# Altium schematic sheet Arduino_DIY.SchDoc(Arduino_DIY)
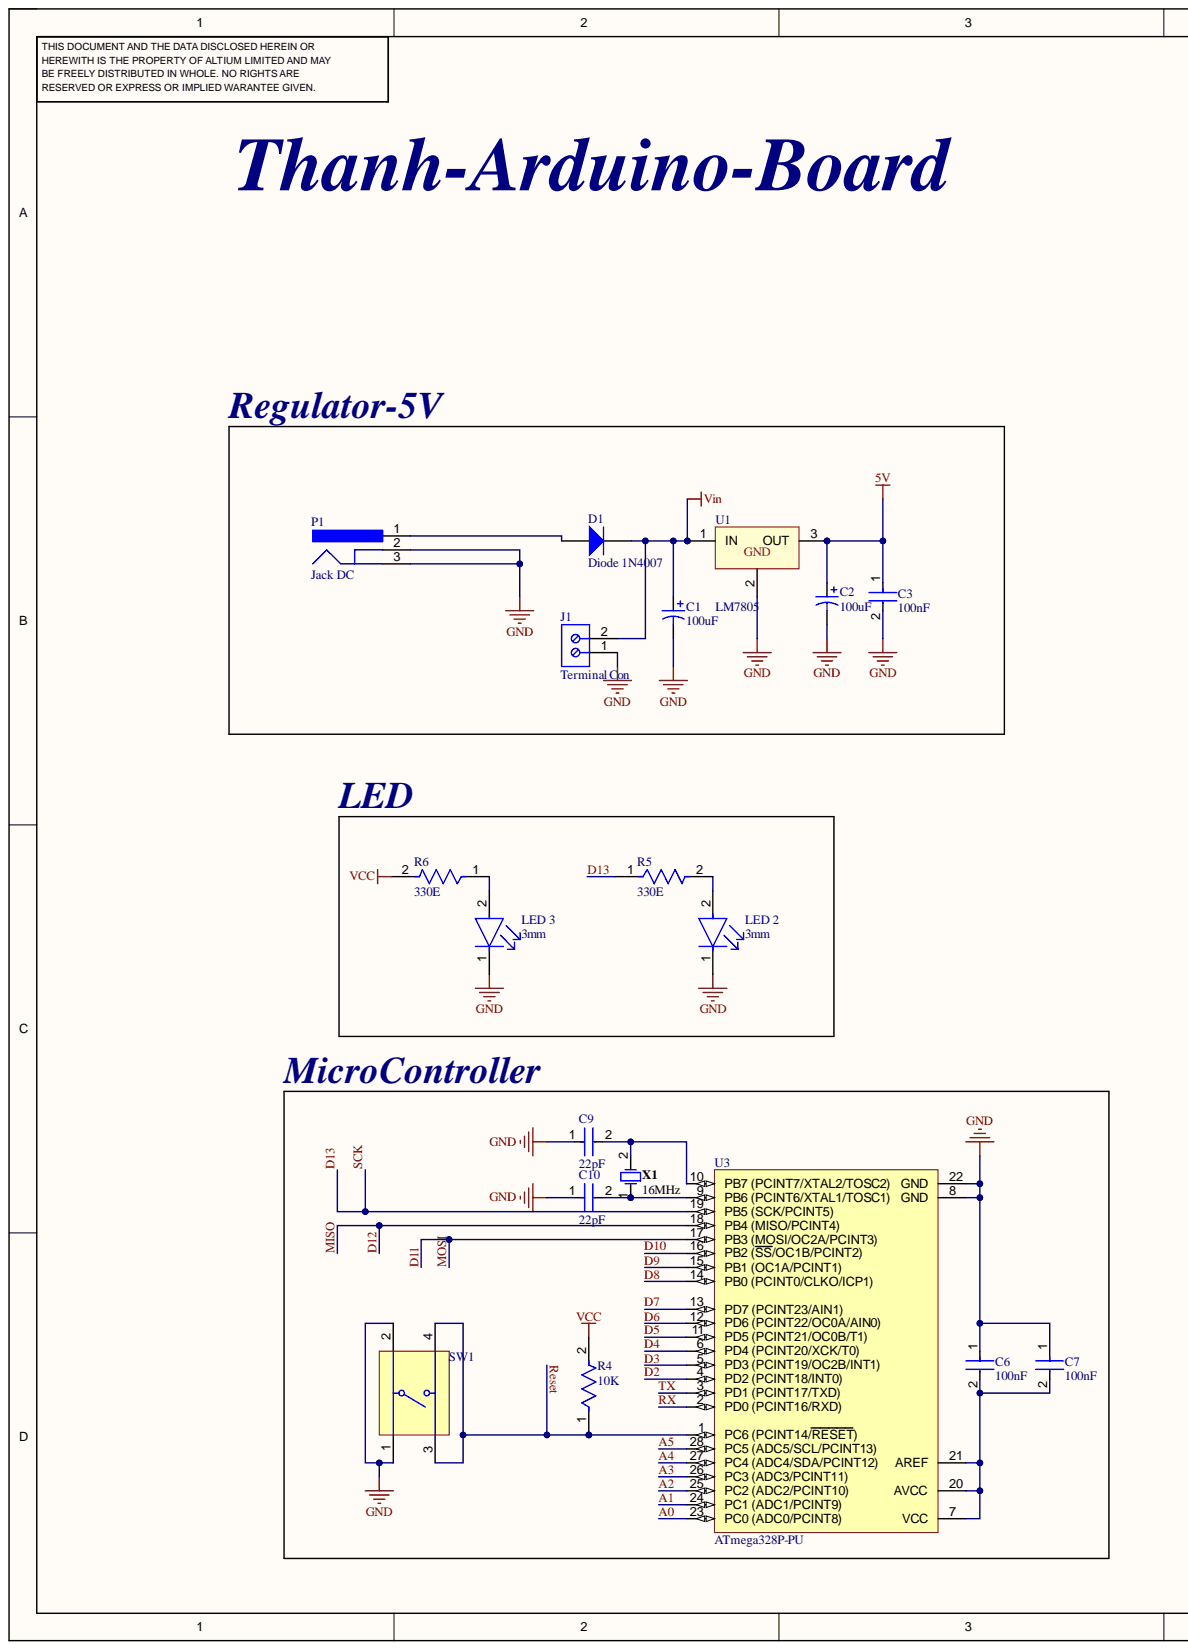
[diagram p0: Arduino_DIY.SchDoc, left]
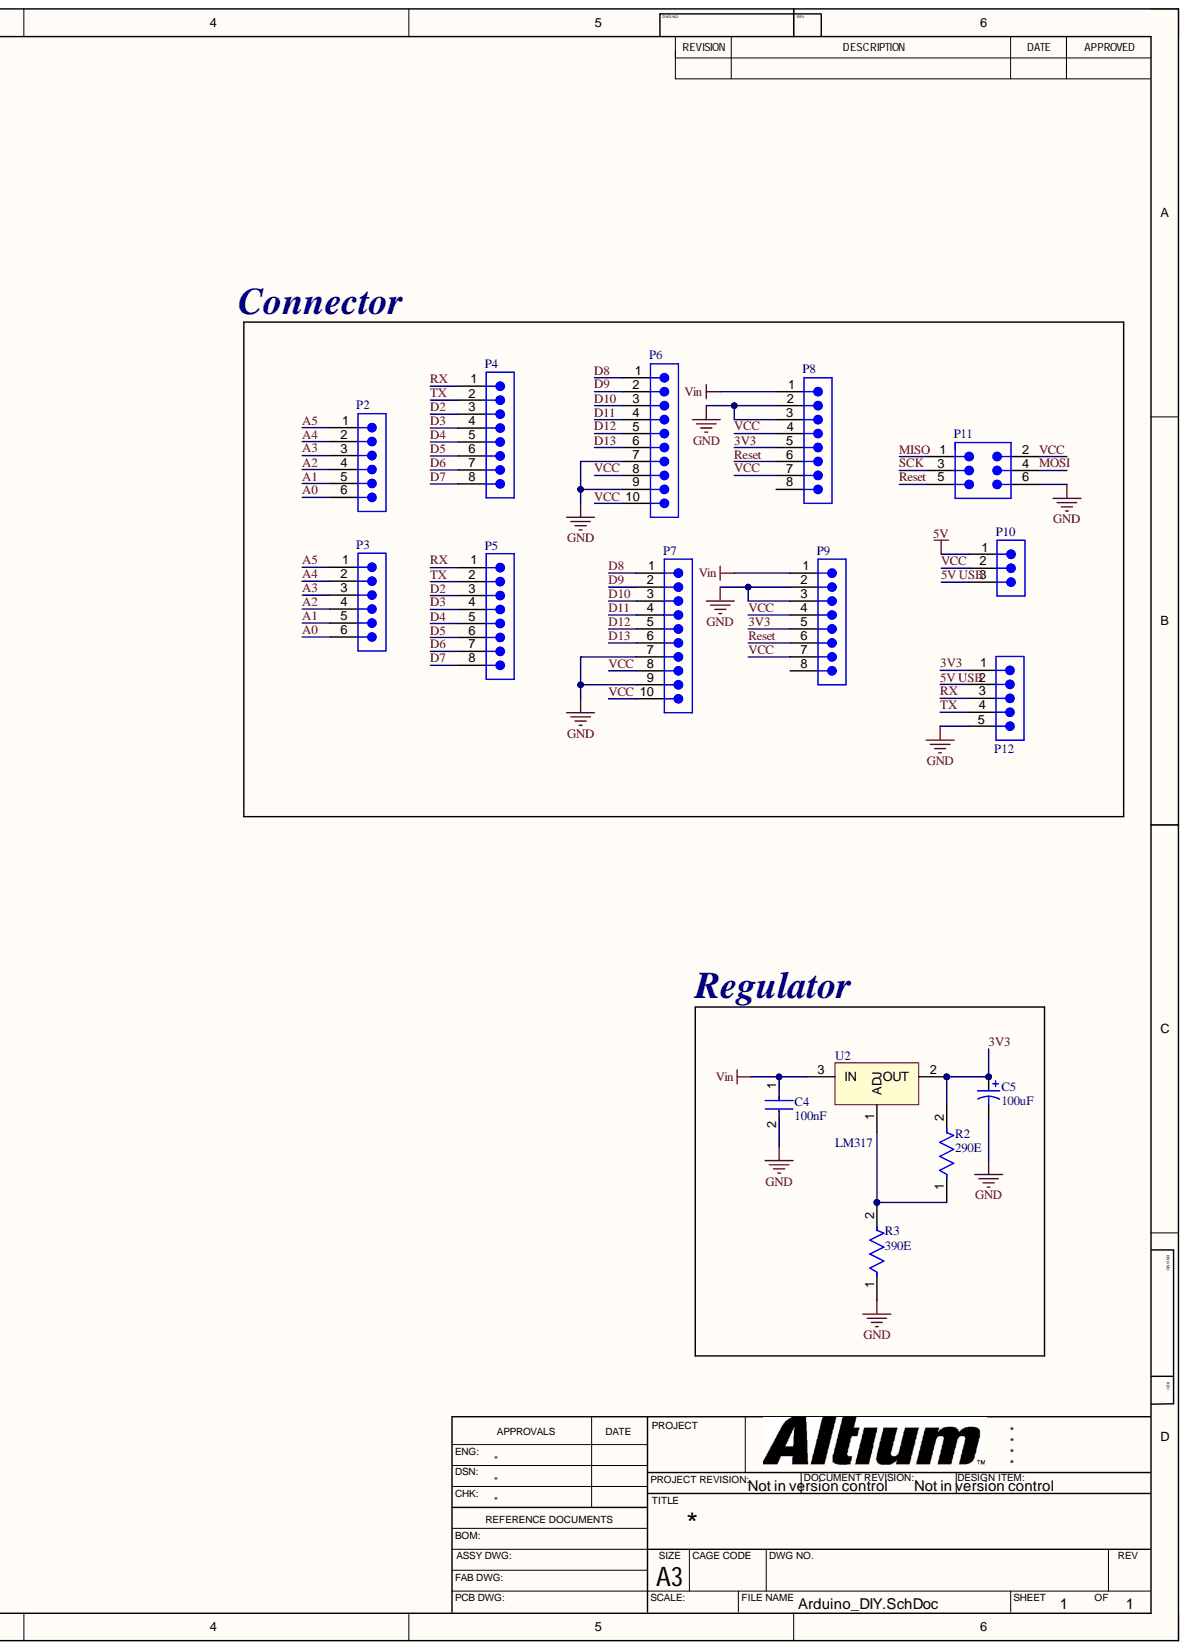
[diagram p1: Arduino_DIY.SchDoc, right]

## Parts
C1  100uF  [CAP_D6.3]
C2  100uF  [CAP_D6.3]
C3  100nF  [FP-RCE0DB-MFG]
C4  100nF  [FP-RCE0DB-MFG]
C5  100uF  [CAP_D6.3]
C6  100nF  [FP-RCE0DB-MFG]
C7  100nF  [FP-RCE0DB-MFG]
C9  22pF  [FP-RCE0DB-MFG]
C10  22pF  [FP-RCE0DB-MFG]
D1  Diode 1N4007  [DO-41]
J1  Terminal Con  [691214110002]
LED_2  3mm  [FP-HLMP-1503-MFG]
LED_3  3mm  [FP-HLMP-1503-MFG]
P1  Jack DC  [KYCN-KLDX-0202-A_V]
P2  Header 6  [61300611121]  (value not drawn)
P3  Header 6  [61300611121]  (value not drawn)
P4  61300811121  [61300811121]  (value not drawn)
P5  61300811121  [61300811121]  (value not drawn)
P6  Header 10  [61301011121]  (value not drawn)
P7  Header 10  [61301011121]  (value not drawn)
P8  61300811121  [61300811121]  (value not drawn)
P9  61300811121  [61300811121]  (value not drawn)
P10  61300311121  [61300311121]  (value not drawn)
P11  61300621121  [61300621121]  (value not drawn)
P12  61300511121  [61300511121]  (value not drawn)
R2  290E  [FP-MRS25-MFG]
R3  390E  [FP-MRS25-MFG]
R4  10K  [FP-MRS25-MFG]
R5  330E  [FP-MRS25-MFG]
R6  330E  [FP-MRS25-MFG]
SW1  Button  [TECO-1825910-6_V]  (value not drawn)
U1  LM7805  [QTO-220]
U2  LM317  [QTO-220]
U3  ATmega328P-PU  [28P3]
X1  16MHz  [FOX-FOXSLF080-20]

## Nets
5V: C2.1 C3.1 P10.1 U1.3
GND: C1.2 C2.2 C3.2 C4.2 C5.2 C6.1 C7.1 C9.1 C10.1 J1.1 LED_2.1 LED_3.1 P1.2 P1.3 P6.7 P6.9 P7.7 P7.9 P8.2 P8.3 P9.2 P9.3 P11.6 P12.5 R3.1 SW1.1 SW1.2 U1.2 U3.8(GND) U3.22(GND)
Vin: C1.1 C4.1 D1.2 J1.2 P8.1 P9.1 U1.1 U2.3
3V3: C5.1 P8.5 P9.5 P12.1 R2.2 U2.2
5V USB: P10.3 P12.2
A0: P2.6 P3.6 U3.23(PC0_(ADC0/PCINT8))
A1: P2.5 P3.5 U3.24(PC1_(ADC1/PCINT9))
A2: P2.4 P3.4 U3.25(PC2_(ADC2/PCINT10))
A3: P2.3 P3.3 U3.26(PC3_(ADC3/PCINT11))
A4: P2.2 P3.2 U3.27(PC4_(ADC4/SDA/PCINT12))
A5: P2.1 P3.1 U3.28(PC5_(ADC5/SCL/PCINT13))
D2: P4.3 P5.3 U3.4(PD2_(PCINT18/INT0))
D3: P4.4 P5.4 U3.5(PD3_(PCINT19/OC2B/INT1))
D4: P4.5 P5.5 U3.6(PD4_(PCINT20/XCK/T0))
D5: P4.6 P5.6 U3.11(PD5_(PCINT21/OC0B/T1))
D6: P4.7 P5.7 U3.12(PD6_(PCINT22/OC0A/AIN0))
D7: P4.8 P5.8 U3.13(PD7_(PCINT23/AIN1))
D8: P6.1 P7.1 U3.14(PB0_(PCINT0/CLKO/ICP1))
D9: P6.2 P7.2 U3.15(PB1_(OC1A/PCINT1))
D10: P6.3 P7.3 U3.16(PB2_(SS/OC1B/PCINT2))
D11: P6.4 P7.4 P11.4 U3.17(PB3_(MOSI/OC2A/PCINT3))
D12: P6.5 P7.5 P11.1 U3.18(PB4_(MISO/PCINT4))
D13: P6.6 P7.6 P11.3 R5.1 U3.19(PB5_(SCK/PCINT5))
Reset: P8.6 P9.6 P11.5 R4.1 SW1.3 SW1.4 U3.1(PC6_(PCINT14/RESET))
RX: P4.1 P5.1 P12.3 U3.2(PD0_(PCINT16/RXD))
TX: P4.2 P5.2 P12.4 U3.3(PD1_(PCINT17/TXD))
VCC: P6.8 P6.10 P7.8 P7.10 P8.4 P8.7 P9.4 P9.7 P10.2 P11.2 R4.2 R6.2
NetC6_2: C6.2 C7.2 U3.7(VCC) U3.20(AVCC) U3.21(AREF)
NetC9_2: C9.2 U3.10(PB7_(PCINT7/XTAL2/TOSC2)) X1.2
NetC10_2: C10.2 U3.9(PB6_(PCINT6/XTAL1/TOSC1)) X1.1
NetD1_1: D1.1 P1.1
NetLED 2_2: LED_2.2 R5.2
NetLED 3_2: LED_3.2 R6.1
NetR2_1: R2.1 R3.2 U2.1

Not connected: P8 8; P9 8

## Library data of the parts
C1 C2 C5 = Cap Pol 6mm: Polarized Capacitor (Axial); Component Kind: Standard; LatestRevisionDate: 17-Jul-2002; LatestRevisionNote: Re-released for DXP Platform.; PackageReference: POLAR0.8; Published: 8-Jun-2000; Library Name: CHN_Library.SchLib; Publisher: Altium Limited
C3 C4 C6 C7 C9 C10 = CMP-06035-020630-1: Cap Ceramic 220pF 50V C0G ±5% Radial 2.5mm +125°C Ammo Pack; Lead Diameter: 0.5mm; Lead/Base Style: Straight; Capacitance: 220pF; Case/Package: Radial; Component Kind: Standard; Depth: 3.5mm; Diameter: 3.6mm; Dielectric: C0G; Height: 3.5mm; Height - Seated (Max): 0.138inch; Lead Pitch: 0.098inch; Length: 3.6mm; Library Name: Altium Content Vault; Max Operating Temperature: 125°C; Min Operating Temperature: -55°C; Mount: Through Hole; Package Quantity: 2000; Packaging: Tape and Reel; Ratings: AEC-Q200; Resistor Type: Ceramic; Termination: Radial; Tolerance: 5%; Voltage: 50V; Voltage Rating: 50V; Voltage Rating (DC): 50V; Width: 0.098inch
D1 = Diode 1N4007: 1 Amp General Purpose Rectifier; Code_JEDEC: DO-204-AL; Component Kind: Standard; ComponentLink1Description: Manufacturer Link; ComponentLink1URL: http://www.fairchildsemi.com/; ComponentLink2Description: Datasheet; ComponentLink2URL: http://www.fairchildsemi.com/ds/1N%2F1N4001.pdf; DatasheetVersion: 1998; LatestRevisionDate: 17-Jul-2002; LatestRevisionNote: Re-released for DXP Platform.; Library Name: Miscellaneous Devices.IntLib; PackageDescription: Diode, Axial; Body 5.2 x 2.7 mm (LxDia. max), Lead Dia. 0.86 mm (max); PackageReference: DO-41; PackageVersion: Aug-1999; Published: 8-Jun-2000; Signal Integrity: 1N4007; Simulation: 1N4007; Publisher: Altium Limited
J1 = CMP-1502-02464-2: NexarPartId: 18818288; Current: 10A; Number of Contacts: 2; Number of Levels: 1; Pitch: 3.5052mm; Plating: Brass, Steel; Stripping Length: -6mm; Voltage: 300V; Wire Gauge (Max): 24AWG; Wire Gauge (Min): 16AWG; Color: Blue, Green; Component Kind: Standard; Contact Material: Brass; Contact Plating: Brass, Tin; Current Rating: 10A; Height: 8.7mm; Housing Material: Nylon; Lead Pitch: 3.5mm; Length: 7.4mm; Library Name: Altium Content Vault; Max Operating Temperature: 105°C; Min Operating Temperature: -40°C; Mount: Through Hole; Number of Pins: 2; Number of Positions Per Level: 2; Number of Rows: 1; Packaging: Box; REACH SVHC: Unknown; RoHS: Compliant; Termination: Screw; Voltage Rating: 300V; Width: 7.9mm; Wire/Cable Gauge: 24AWG
LED_2 LED_3 = CMP-18399-000010-1: LED GREEN DIFFUSED T-1 T/H; Manufacturer: Broadcom; Forward Current: 10mA; Forward Voltage: 2.1V; Led Orientation: Straight; Luminous Intensity: 1mcd; Max Junction Temp: +110°C; Peak Emmision Wavelength: 565nm; Reverse Voltage: 5V; Standoff Height: 0.88mm; Viewing Angle: 60°; Wavelength: 569nm; Automotive: No; Category: Diode; Colour: Green; Component Kind: Standard; ComponentLink2Description: Datasheet; ComponentLink2URL: https://docs.broadcom.com/docs/AV02-1555EN; Device Class L1: Optoelectronics; Device Class L2: LEDs; Device Class L3: unset; Digikey Description: LED GREEN DIFFUSED T-1 T/H; Height: 6.35mm; Lead Free: Yes; Library Name: Altium Content Vault; Manufacturer Part Number: HLMP-1503; Mouser Description: LED; Green; 1mcd; 0.115In.Dia.; T-1; 565nm; 25mA; 2.1V; 5V; GaP; -20degC; 100deg; Octopart: https://octopart.com/hlmp-1503-broadcom-71130371; Package: HLMP-1503; RoHS: TRUE; Temperature: -20°C to +100°C; Temperature Range High: +100°C; Temperature Range Low: -20°C
P1 = CMP-1673-00001-5: DC Power Jack, 24 V, 3.5 A, -25 to 85 degC, 3-Pin THD, RoHS; Manufacturer: Kycon; Current Rating: 3.5 A; Voltage Rating: 24 V; Colour: Black; Component Kind: Standard; ComponentLink1Description: Manufacturer URL; ComponentLink1URL: http://www.kycon.com/; ComponentLink2Description: Datasheet; ComponentLink2URL: http://www.kycon.com/Pub_Eng_Draw/KLDX-0202-X.PDF; Connector Type: Power; Contact Gender: Female; Contact Material: Brass; Contact Plating: Tin; DatasheetVersion: Rev. D3, 06/2013; Height: 10.8 mm; Housing Material: PBT; Length: 9.2 mm; Library Name: Altium Content Vault; Max Operating Temperature: 85 degC; Min Operating Temperature: -25 degC; Mount: Through Hole; Mounting Technology: Through Hole; Package Quantity: 500; PackageDescription: 3-Pin Through Hole Device, Body 14.5 x 9 mm; PackageReference: KLDX-0202-A; Pins: 2; RoHSCompliant: true
P2 P3 = CMP-1502-01070-3: NexarPartId: 20084590; Connector Type: Male Pin; Contact Resistance: 20mΩ; Current Rating: 3A; Gender: Male; Number of Contacts: 6; Operating Supply Voltage: 250V; Pitch: 2.54mm; Color: Black; Component Kind: Standard; Contact Gender: Male; Contact Material: Copper; Contact Mating Length: 5.9944mm; Contact Plating: Gold; Height: 8.89mm; Housing Material: PBT; Lead Free: Lead Free; Lead Pitch: 2.54mm; Library Name: Altium Content Vault; Max Operating Temperature: 105°C; Min Operating Temperature: -40°C; Mount: Through Hole; Number of Pins: 6; Number of Positions: 6; Number of Rows: 1; Orientation: Vertical; Packaging: Bulk; REACH SVHC: Unknown; RoHS: Compliant; Termination: Through Hole; Voltage Rating: 250V
P4 P5 P8 P9 = CMP-1502-01076-3: NexarPartId: 32855533; Connector Type: Male Pin; Contact Resistance: 20mΩ; Current Rating: 3A; Gender: Male; Number of Contacts: 8; Operating Supply Voltage: 250V; Pitch: 2.54mm; Color: Black; Component Kind: Standard; Contact Material: Copper; Contact Mating Length: 5.9944mm; Contact Plating: Gold; Height: 8.54mm; Lead Pitch: 2.54mm; Library Name: Altium Content Vault; Max Operating Temperature: 105°C; Min Operating Temperature: -40°C; Mount: Through Hole; Number of Pins: 8; Number of Positions: 8; Number of Rows: 1; Orientation: Vertical; Packaging: Bulk; REACH SVHC: Unknown; RoHS: Compliant; Termination: Solder; Voltage Rating: 250V
P6 P7 = CMP-1502-01082-5: NexarPartId: 18818159; Connector Type: Male Pin; Contact Resistance: 20mΩ; Current Rating: 3A; Gender: Male; Insulation Resistance: 1GΩ; Number of Contacts: 10; Operating Supply Voltage: 250V; Pitch: 2.54mm; Color: Black; Component Kind: Standard; Contact Gender: Male; Contact Material: Copper; Contact Mating Length: 5.9944mm; Contact Plating: Gold; Flammability Rating: UL94 V-0; Height: 8.74mm; Housing Material: PBT; Lead Pitch: 2.54mm; Length: 2.54mm; Library Name: Altium Content Vault; Max Operating Temperature: 105°C; Min Operating Temperature: -40°C; Mount: Through Hole; Number of Pins: 10; Number of Positions: 10; Number of Rows: 1; Orientation: Vertical; Packaging: Bulk; REACH SVHC: Unknown; RoHS: Compliant; Termination: Solder; Voltage Rating: 250V; Width: 25.4mm
P10 = CMP-1502-01062-3: NexarPartId: 32855521; Connector Type: Male Pin; Contact Resistance: 20mΩ; Current Rating: 3A; Gender: Male; Number of Contacts: 3; Operating Supply Voltage: 250V; Pitch: 2.54mm; Color: Black; Component Kind: Standard; Contact Material: Copper; Contact Mating Length: 5.9944mm; Contact Plating: Gold; Height: 8.94mm; Lead Free: Lead Free; Lead Pitch: 2.54mm; Library Name: Altium Content Vault; Max Operating Temperature: 105°C; Min Operating Temperature: -40°C; Mount: Through Hole; Number of Pins: 3; Number of Positions: 3; Number of Rows: 1; Orientation: Vertical; Packaging: Bulk; REACH SVHC: Unknown; RoHS: Compliant; Termination: Solder; Voltage Rating: 250V
P11 = CMP-1502-01072-3: NexarPartId: 32855529; Connector Type: Male Pin; Contact Resistance: 20mΩ; Current Rating: 3A; Gender: Male; Number of Contacts: 6; Operating Supply Voltage: 250V; Pitch: 2.54mm; Color: Black; Component Kind: Standard; Contact Material: Copper; Contact Mating Length: 5.9944mm; Contact Plating: Gold; Height: 8.89mm; Lead Pitch: 2.54mm; Library Name: Altium Content Vault; Max Operating Temperature: 105°C; Min Operating Temperature: -40°C; Mount: Through Hole; Number of Pins: 6; Number of Positions: 6; Number of Rows: 2; Orientation: Vertical; Packaging: Bulk; REACH SVHC: Unknown; RoHS: Compliant; Row Spacing: 2.54mm; Termination: Solder; Voltage Rating: 250V
P12 = CMP-1502-01068-3: NexarPartId: 32855526; Connector Type: Male Pin; Contact Resistance: 20mΩ; Current Rating: 3A; Gender: Male; Number of Contacts: 5; Operating Supply Voltage: 250V; Pitch: 2.54mm; Color: Black; Component Kind: Standard; Contact Material: Copper; Contact Mating Length: 5.9944mm; Contact Plating: Gold; Height: 8.89mm; Lead Free: Lead Free; Lead Pitch: 2.54mm; Library Name: Altium Content Vault; Max Operating Temperature: 105°C; Min Operating Temperature: -40°C; Mount: Through Hole; Number of Pins: 5; Number of Positions: 5; Number of Rows: 1; Orientation: Vertical; Packaging: Bulk; REACH SVHC: Unknown; RoHS: Compliant; Termination: Solder; Voltage Rating: 250V
R2-R6 = CMP-02408-000051-1: RES 1K OHM 0.6W 1% AXIAL; Lead Diameter: 0.6mm; Max Power Dissipation: 600mW; Resistance: 1kR; Temperature Coefficient: 50ppm/°C; Voltage Rating: 350V; Case/Package: Axial; Component Kind: Standard; Construction: Cylindrical; Contact Plating: Tin; Diameter: 2.5mm; Lead Free: Lead Free; Length: 6.5mm; Library Name: Altium Content Vault; Max Operating Temperature: 155°C; Military Standard: Not; Min Operating Temperature: -55°C; Mount: Through Hole; Number of Pins: 2; Number of Terminations: 2; Package Quantity: 5000; Packaging: Tape and Reel; Power Rating: 600mW; Radiation Hardening: No; Resistance Tolerance: 1%; Resistor Type: Metal Film; RoHS Compliant: Yes; Series: MRS25; Technology: THIN FILM; Termination: Axial; Tolerance: 1%; Voltage Rating (DC): 350V; Weight: 0.00776oz; Width: 2.5mm
SW1 = CMP-1684-00021-1: Tact Switch, SPST-NO, 0.05 A, -35 to 85 degC, 4-Pin THD, RoHS, Bulk; Manufacturer: TE Connectivity; Part No: 1825910-6; Actuator Color: Black; Actuator Length: 1.4mm; Actuator Material: PolybutyleneTerephthalate; Actuator Style: RoundButton; Body Orientation: Straight; Bushing Type: Unthreaded; Contact Rating @ Voltage: 0.05A@24VDC; Dielectric Strength: 500VAC; Electrical Life: 100000Cycles; Function: MomentaryContact; Illumination: N/R; Maximum Contact Resistance: 100mOhm; Maximum DC Voltage Rating: 24V; Pole Throw Configuration: SPST; Switch Configuration: OFF(ON); Base Material: N/A; Component Kind: Standard; Contact Material: CopperAlloy; Contact Plating: Silver; Datasheet URL: http://www.te.com/commerce/DocumentDelivery/DDEController?Action=showdoc&DocId=Customer+Drawing%7F1825910%7FC9%7Fpdf%7FEnglish%7FENG_CD_1825910_C9.pdf%7F1825910-6; Datasheet Version: Rev. C9; Dimension: 5.99x5mm; Family: 1825910; Housing Material: N/A; Library Name: Altium Content Vault; Manufacturer URL: http://www.te.com; Maximum AC Voltage Rating: N/A; Mechanical Life: 100000Cycles; Minimum Insulation Resistance: 100MOhm; Mounting: ThroughHole; Operating Temperature: -35to85C; Package Description: 4-Pin Through Hole Device, Body 5.99 x 5.99 mm, Pitch 6.5 mm; Package Reference: THD; Packaging: N/A; Product Weight: N/A; RoHS: Yes; Seal Description: N/A; Seal Location: N/A; Terminal Type: PCPins; Thread Size: N/R
U1 = LM78xx: On ap +, from + 5 to + 24VDC; Component Kind: Standard; Value: LM7805; Library Name: CHN_Library.SchLib
U2 = LM317: Adj Voltage +1.2 -> +37V; Component Kind: Standard; Value: LM317; Library Name: CHN_Library.SchLib
U3 = CMP-0095-00013-2: 8-bit AVR Microcontroller, 32KB Flash, 1KB EEPROM, 2KB SRAM, 28-pin PDIP, Industrial Grade (-40°C to 85°C); Component Kind: Standard; ComponentLink1Description: Manufacturer URL; ComponentLink1URL: http://www.atmel.com/; ComponentLink2Description: ATmega48A/48PA/88A/88PA/168A/168PA/328/328P Datasheet; ComponentLink2URL: http://www.atmel.com/dyn/resources/prod_documents/8271.pdf; ComponentLink3Description: ATmega48A/48PA/88A/88PA/168A/168PA/328/328P Summary; ComponentLink3URL: http://www.atmel.com/dyn/resources/prod_documents/8271S.pdf; DatasheetVersion: revD, May-2011; Library Name: Altium Content Vault; PackageDescription: 28-lead (0.300"/7.62mm Wide) Plastic Dual Inline Package (PDIP); PackageReference: 28P3; PackageVersion: revB, Sep-2001; RevisionNote: DatasheetVersion updated. URLs added.
X1 = CMP-1749-00002-1: Resistance Weld Thru-Hole Crystal, 8 MHz, -20 to 70 degC, 2-Pin THD, RoHS, Bulk; Case\Package: TO-263-3; Dropout Voltage: 2 V; Max Input Voltage: 35 V; Max Ouput Current: 1 A; Min Input Voltage: 7 V; Nominal Output Voltage: 5 V; Outputs: 1; Component Kind: Standard; ComponentLink1Description: Manufacturer URL; ComponentLink1URL: http://www.foxonline.com/; ComponentLink2Description: Datasheet; ComponentLink2URL: http://download.siliconexpert.com/pdfs/2015/4/5/6/5/7/200/fox_/manual/35607121449963728hc49slf.pdf; DatasheetVersion: Mar-15; Height: 30.02 mm; Length: 10.24 mm; Library Name: Altium Content Vault; Max Operating Temperature: 125 degC; Min Operating Temperature: 0 degC; Mount: Surface Mount; Output Current: 1 A; Output Voltage: 5 V; Package Quantity: 50; PackageDescription: 2-Pin Through Hole Device, Body 11.35 x 4.65 mm; PackageReference: FOXSLF080-20; Packaging: Rail/Tube; Pins: 3; Radiation Hardening: No; REACH SVHC: No SVHC; RoHSCompliant: true; Width: 9.35 mm
